# Altium schematic sheet P07-LCD.SchDoc
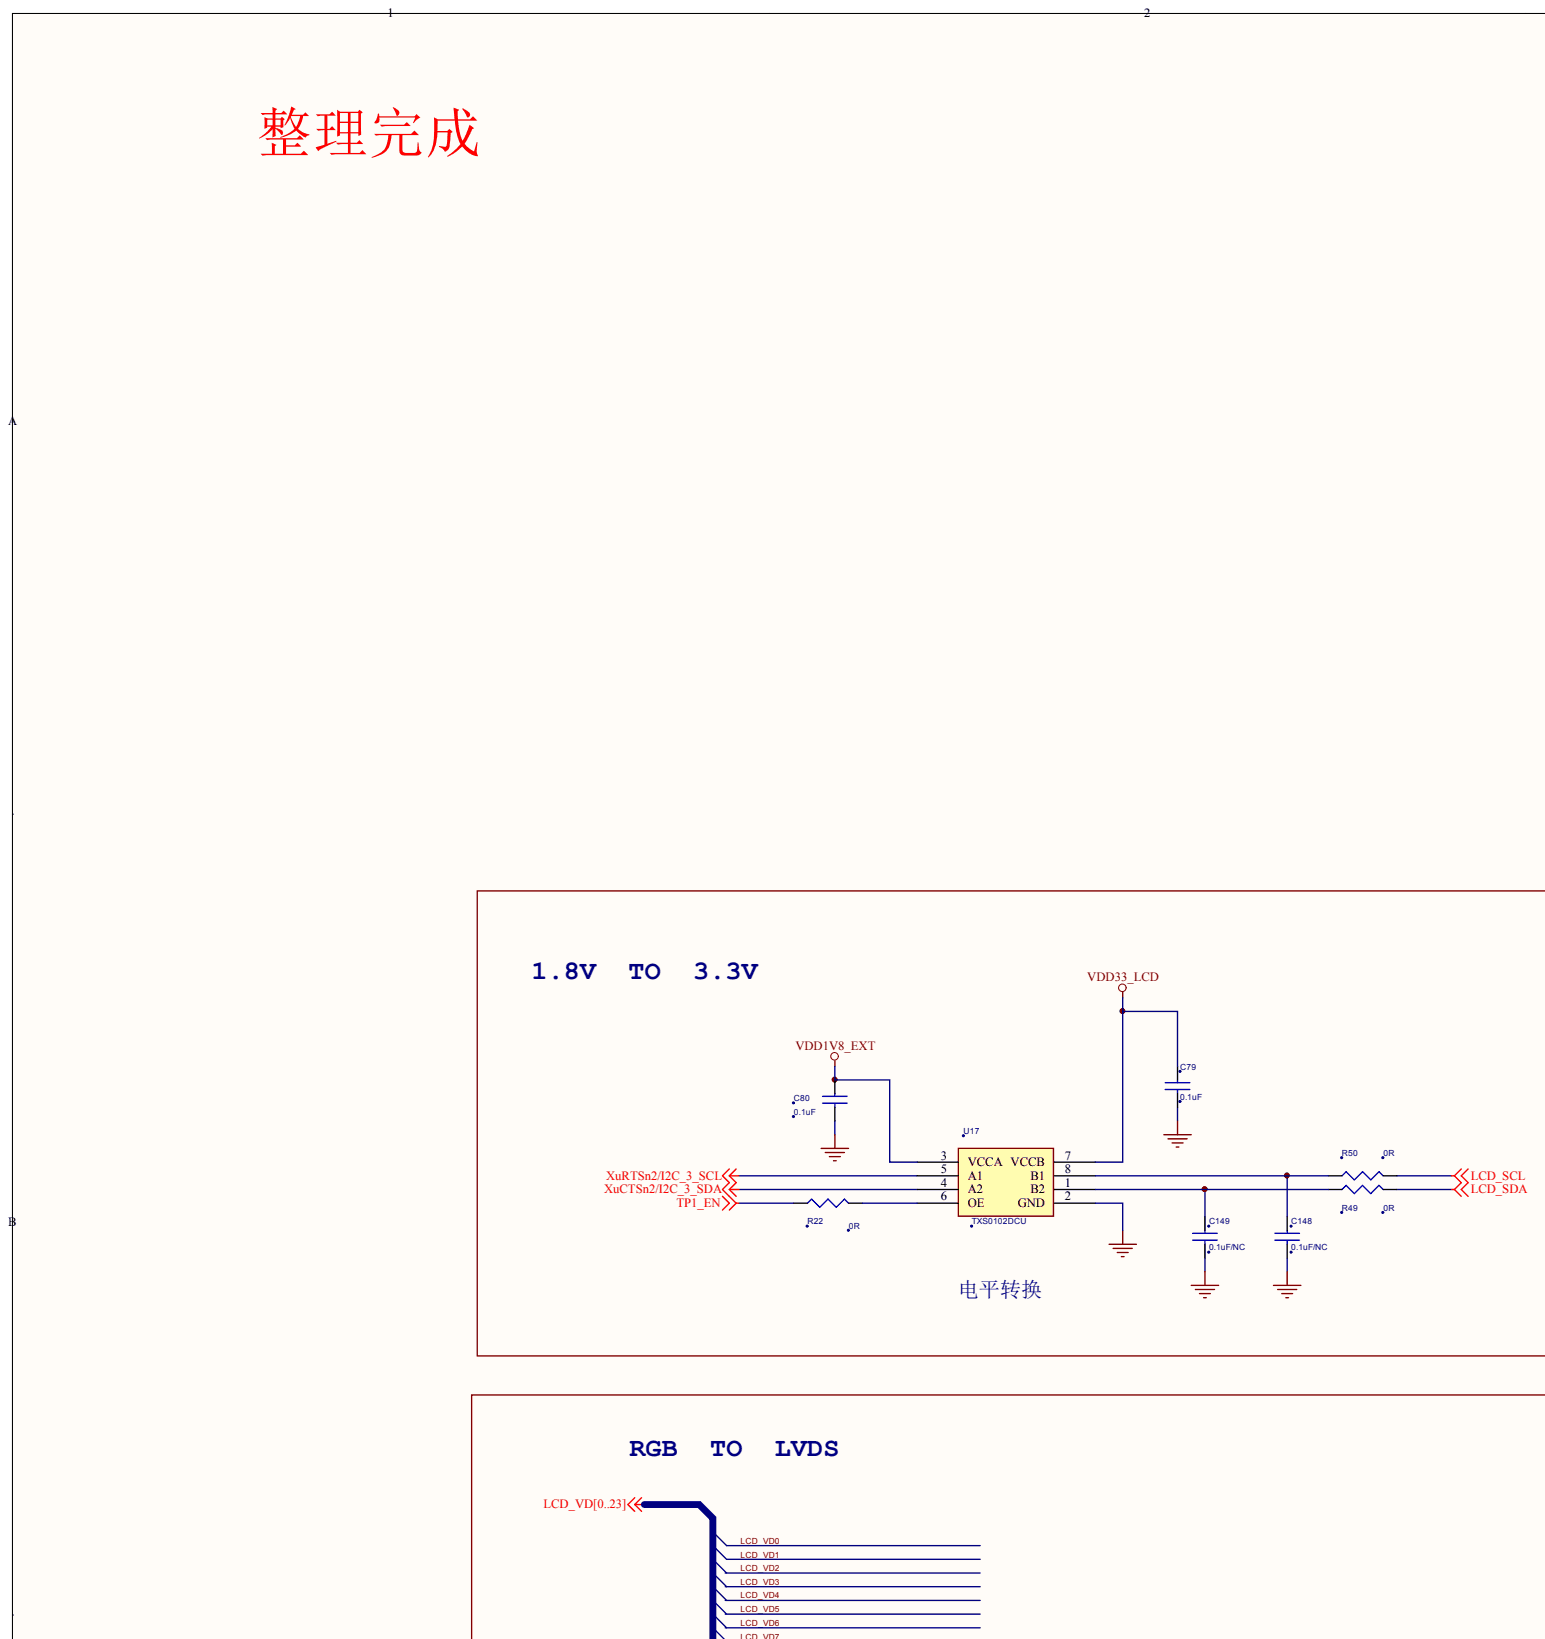
[diagram p0: P07-LCD.SchDoc, top left]
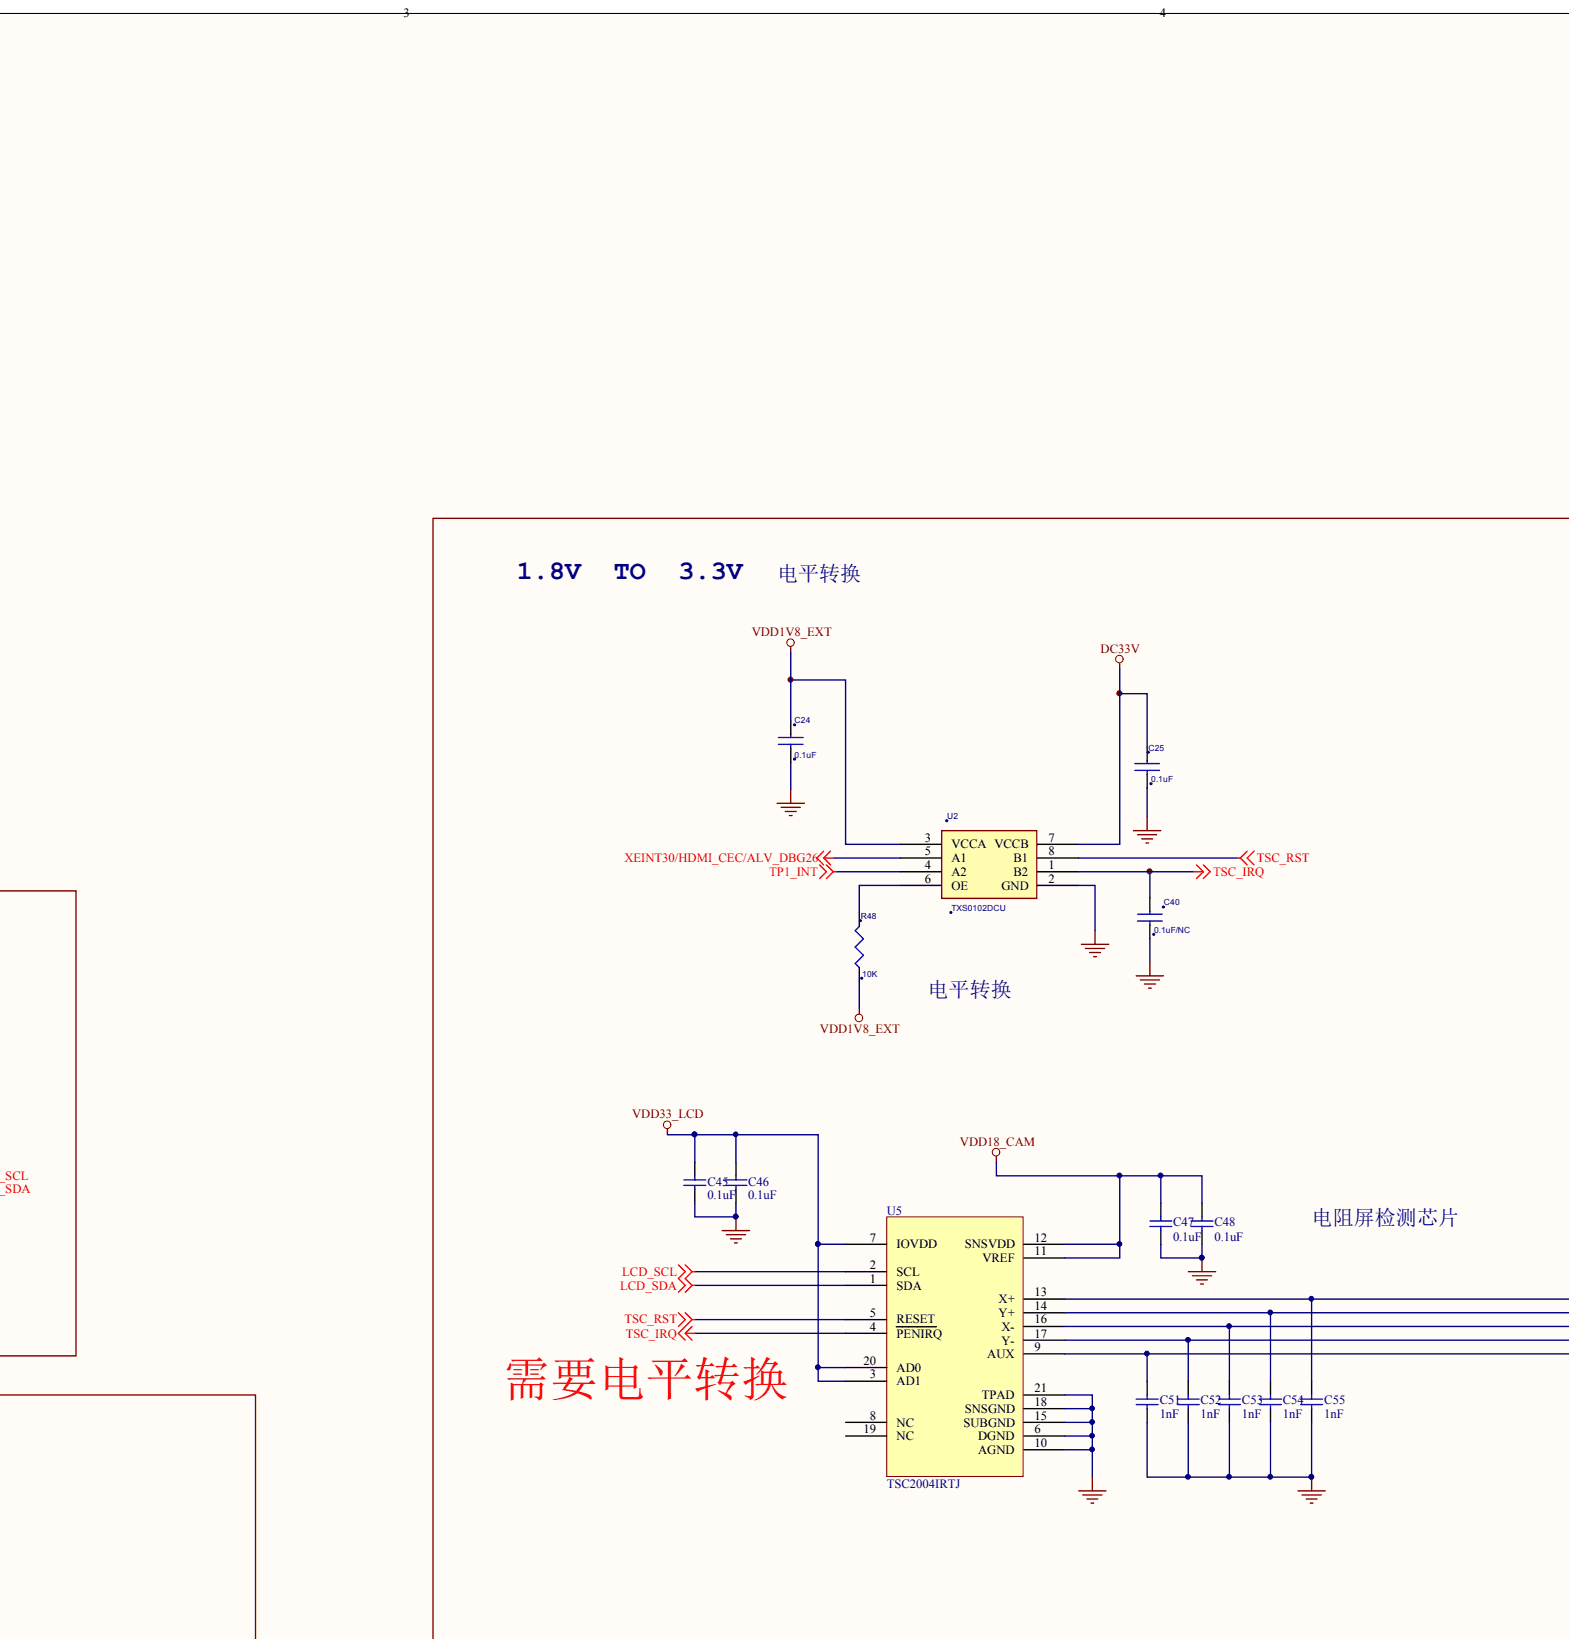
[diagram p1: P07-LCD.SchDoc, top centre]
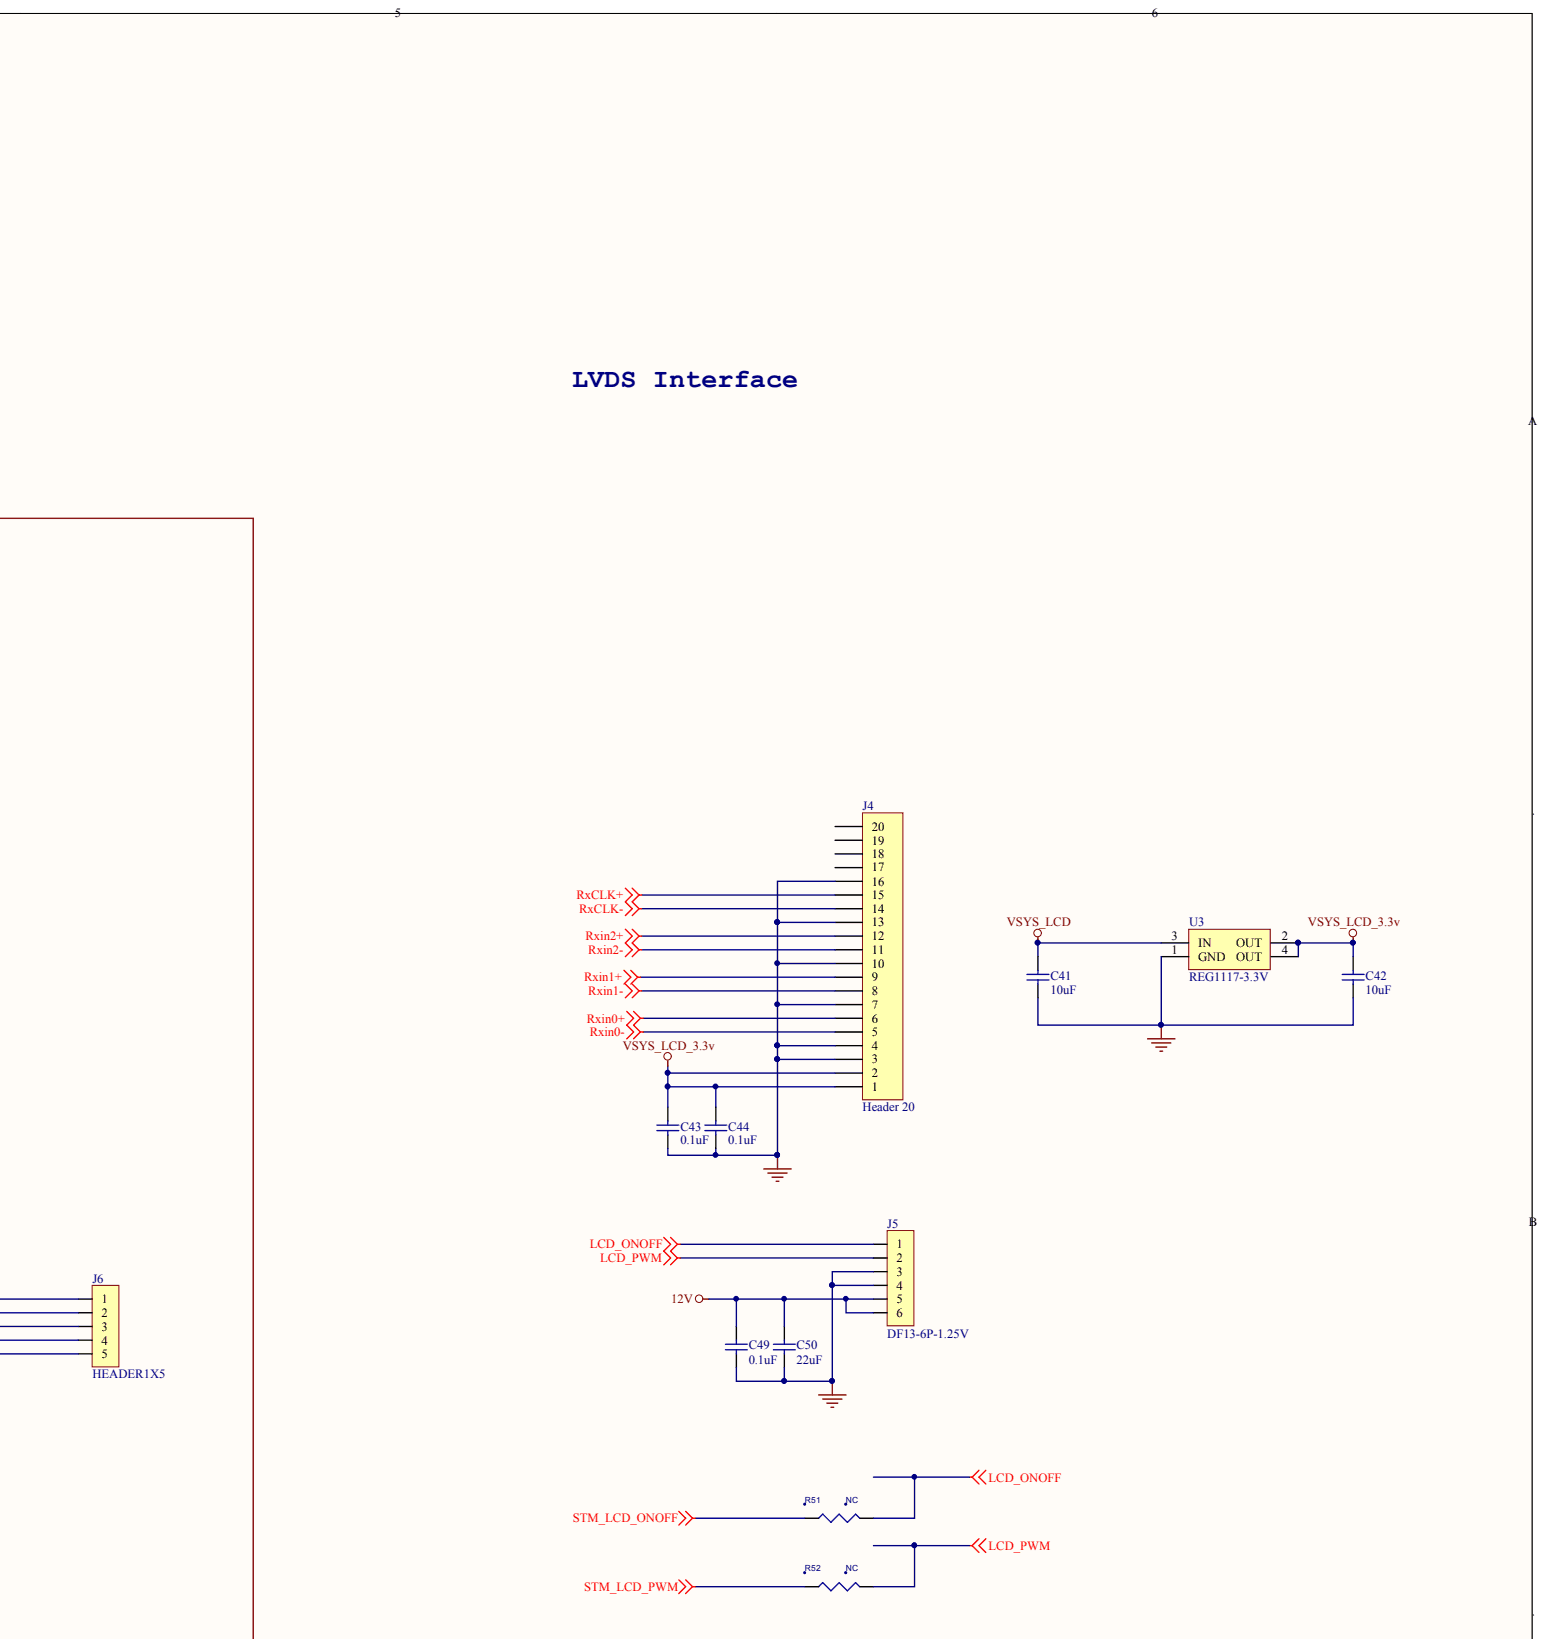
[diagram p2: P07-LCD.SchDoc, top right]
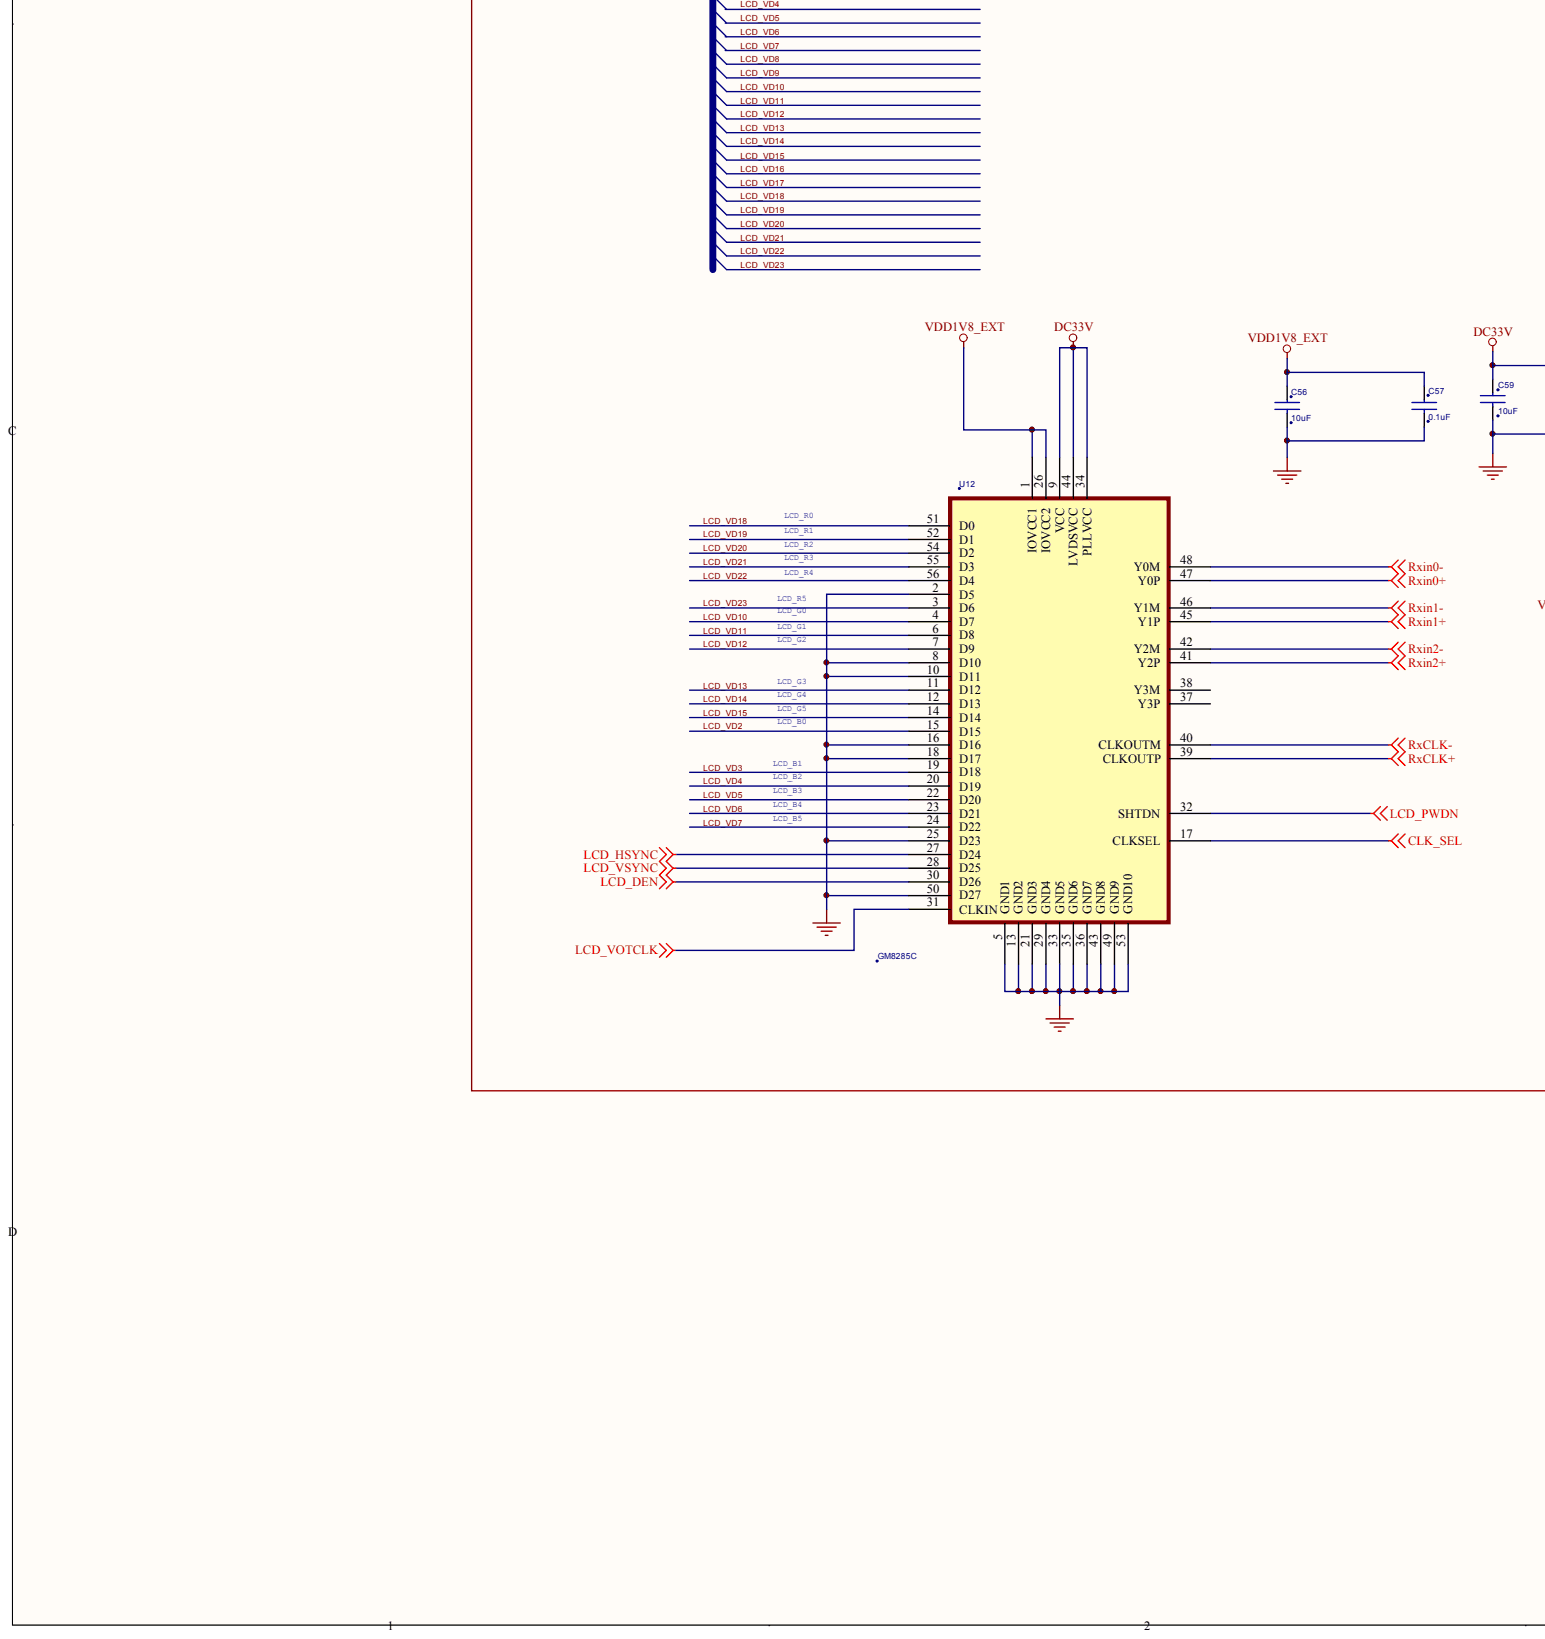
[diagram p3: P07-LCD.SchDoc, bottom left]
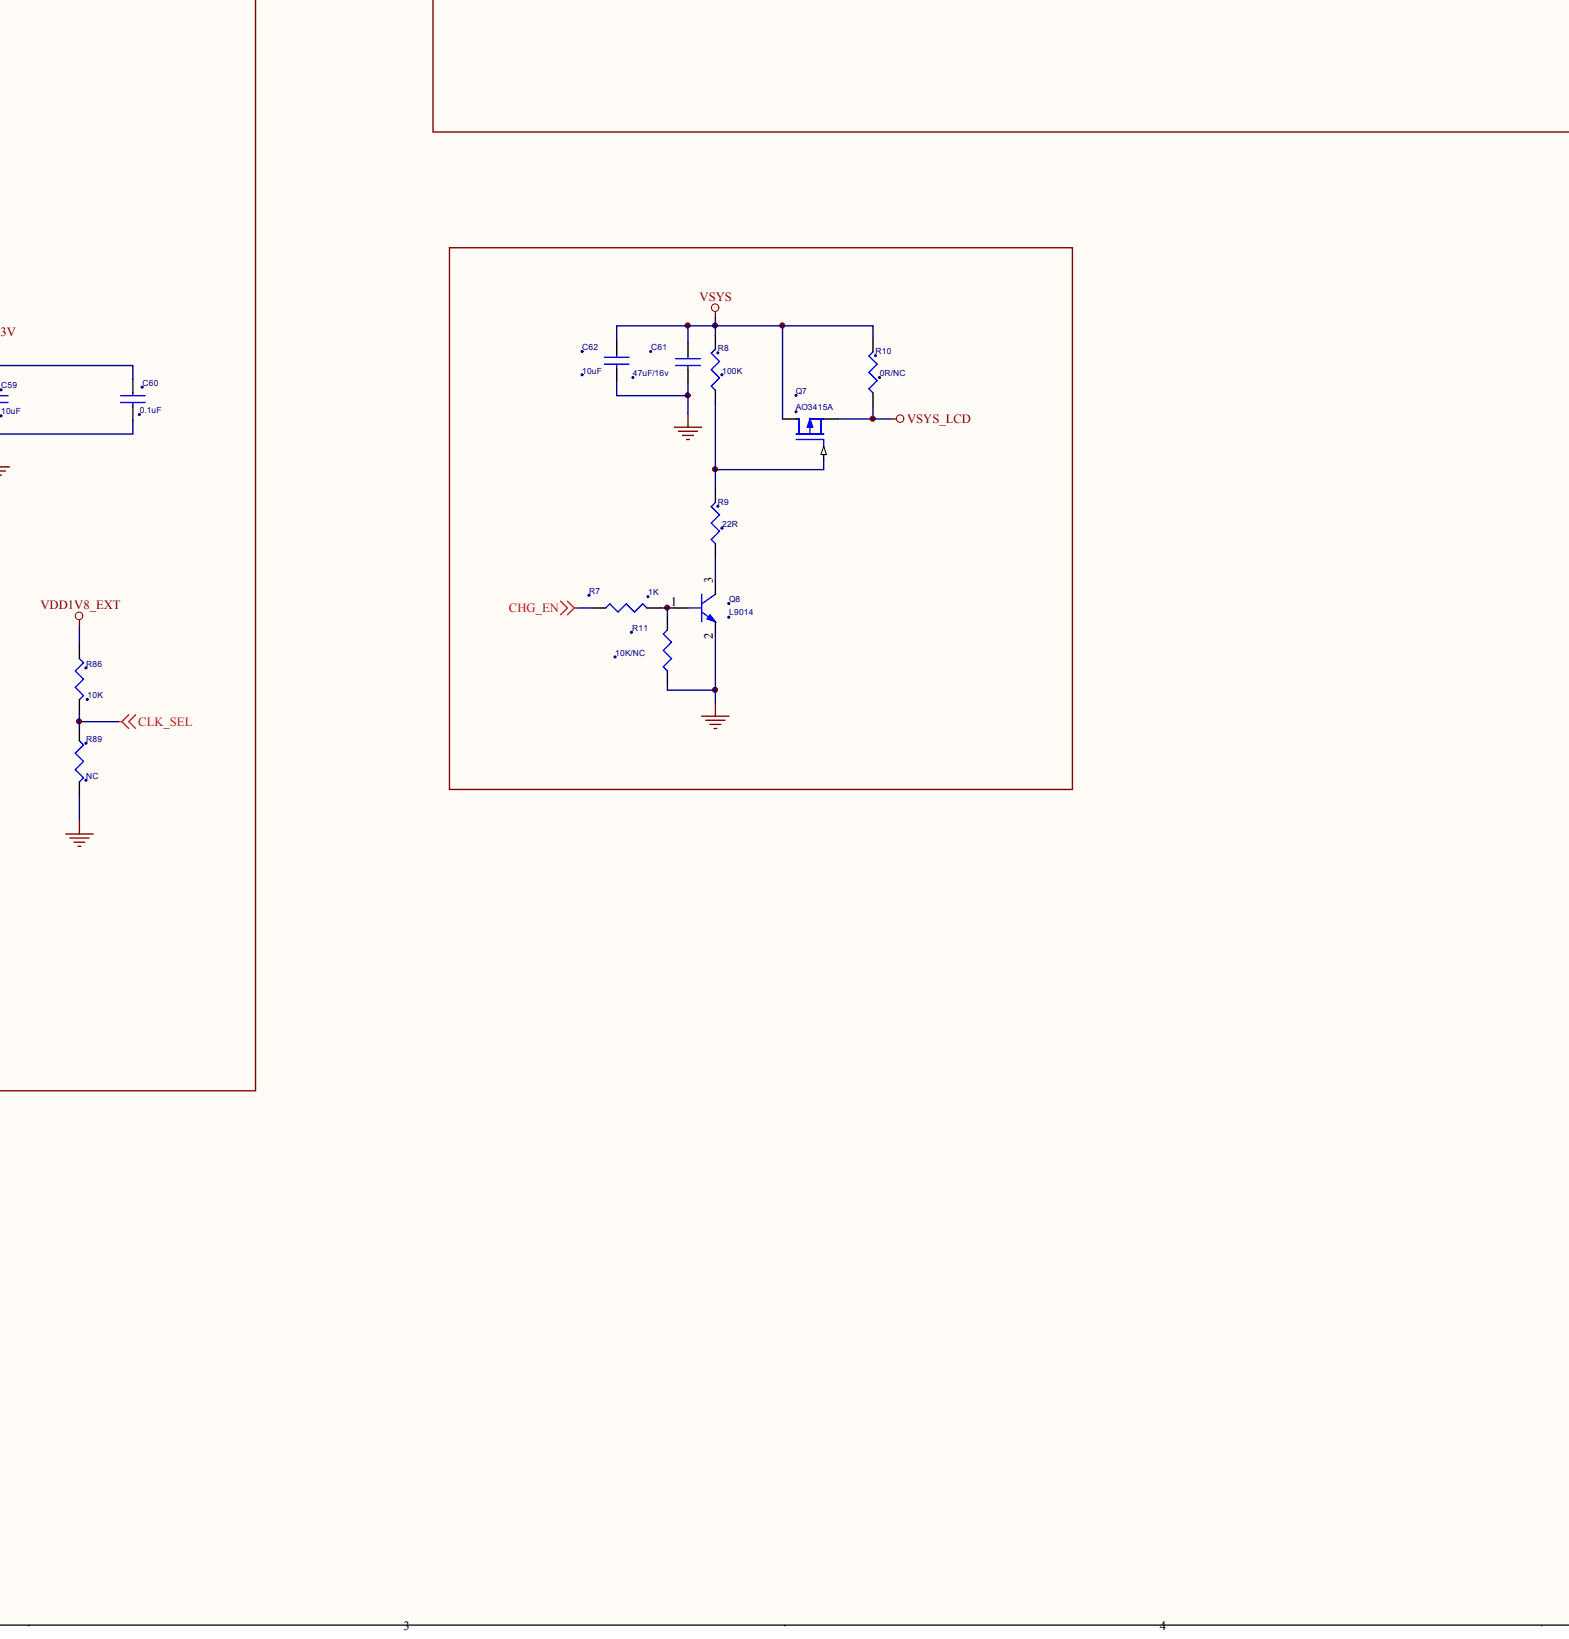
[diagram p4: P07-LCD.SchDoc, bottom centre]
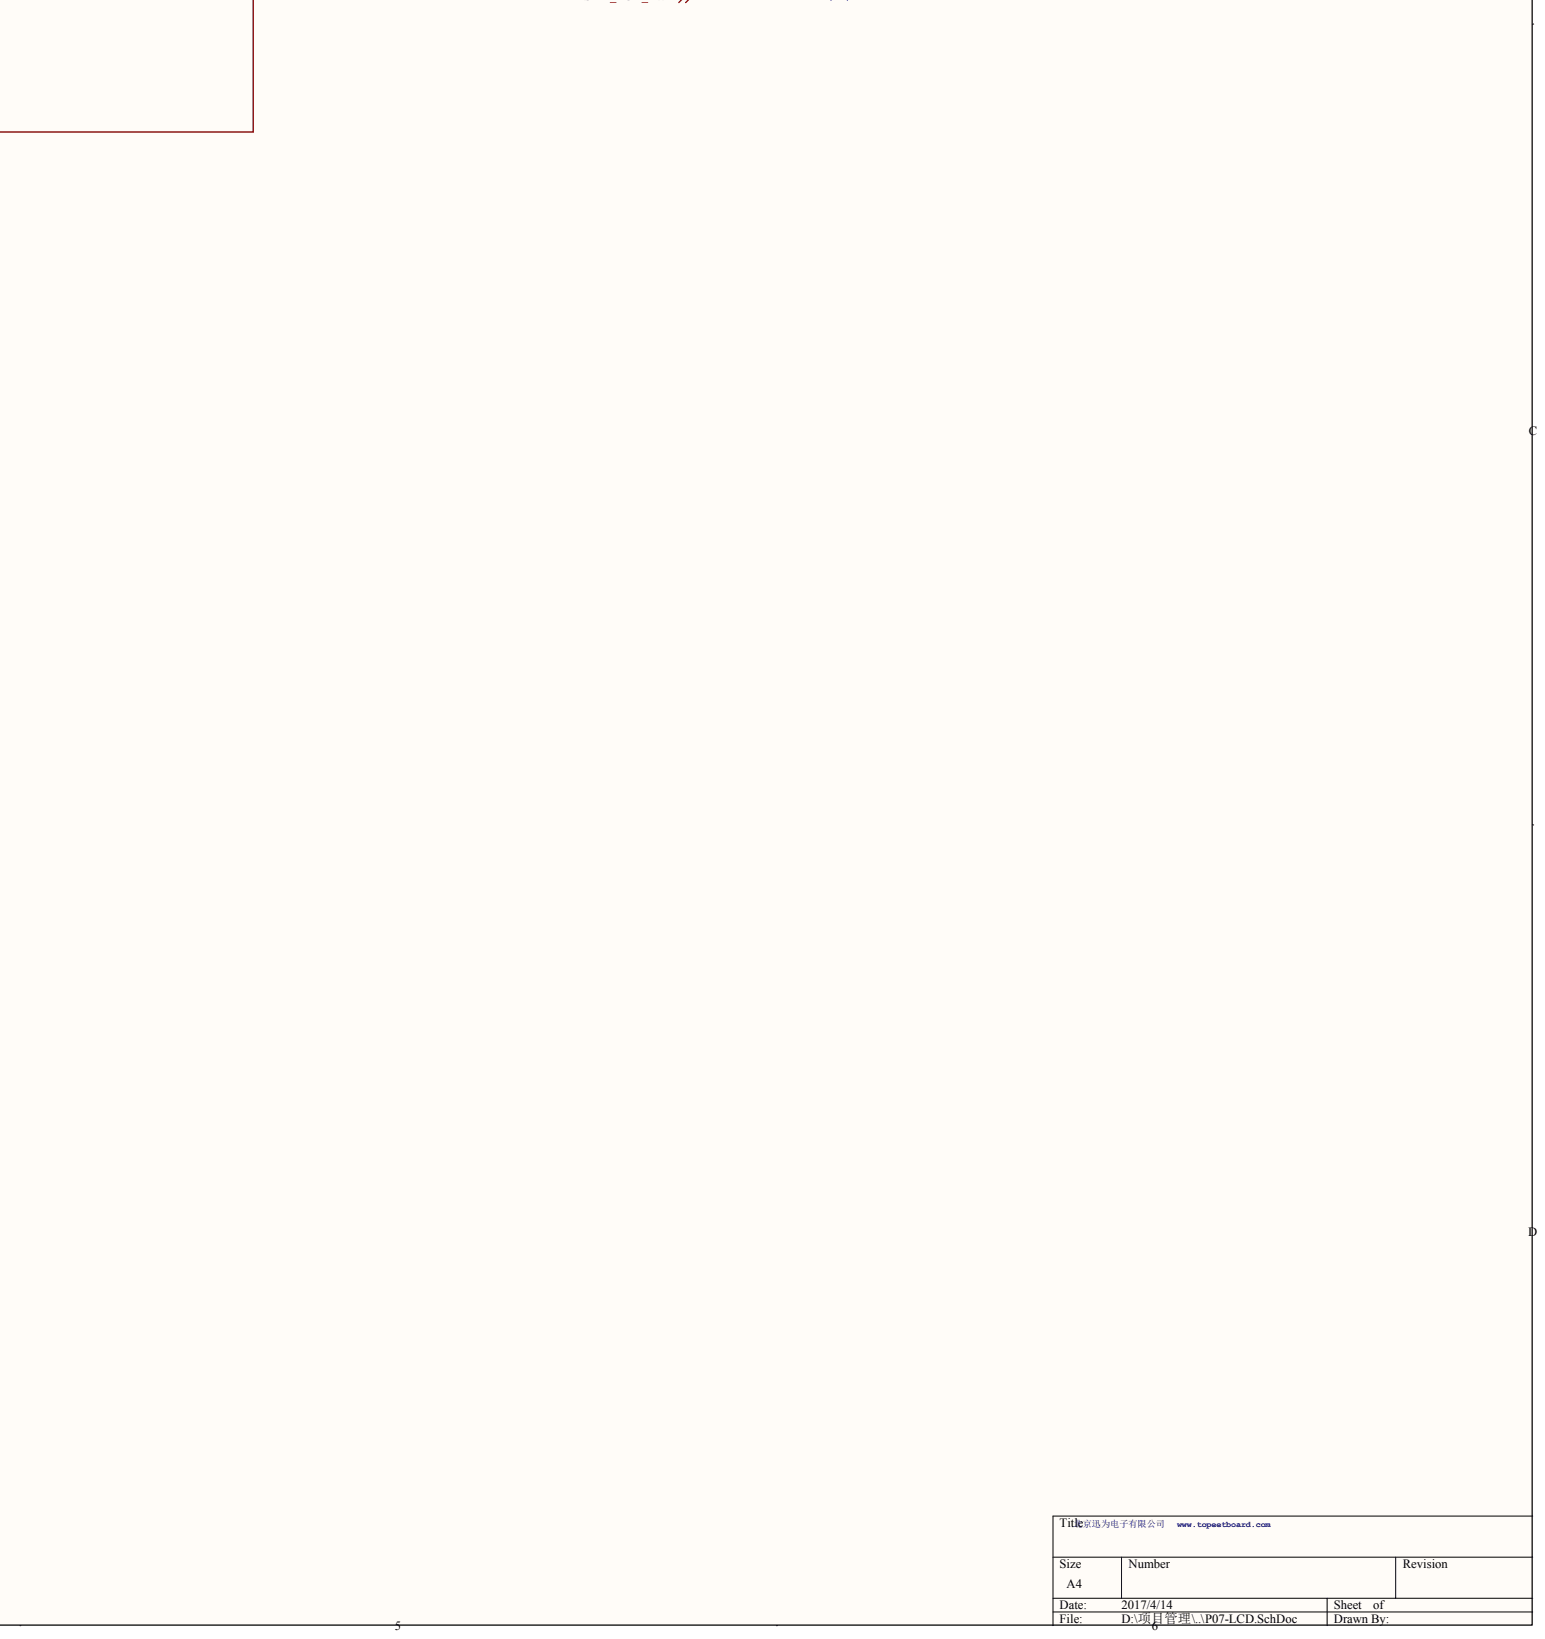
[diagram p5: P07-LCD.SchDoc, bottom right]

## Parts
C24  0.1uF
C25  0.1uF
C40  0.1uF/NC
C41  10uF
C42  10uF
C43  0.1uF
C44  0.1uF
C45  0.1uF
C46  0.1uF
C47  0.1uF
C48  0.1uF
C49  0.1uF
C50  22uF
C51  1nF
C52  1nF
C53  1nF
C54  1nF
C55  1nF
C56  10uF
C57  0.1uF
C59  10uF
C60  0.1uF
C61  47uF/16v
C62  10uF
C79  0.1uF
C80  0.1uF
C148  0.1uF/NC
C149  0.1uF/NC
J4  Header 20
J5  DF13-6P-1.25V
J6  HEADER1X5
Q7  AO3415A
Q8  L9014
R7  1K
R8  100K
R9  22R
R10  0R/NC
R11  10K/NC
R22  0R
R48  10K
R49  0R
R50  0R
R51  NC
R52  NC
R86  10K
R89  NC
U2  TXS0102DCU
U3  REG1117-3.3V
U5  TSC2004IRTJ
U12  GM8285C
U17  TXS0102DCU

## Nets
12V: C49.2 C50.2 J5.5 J5.6
CHG_EN: R7.2
CLK_SEL: R86.2 R89.1 U12.17(CLKSEL)
DC33V: C25.1 C59.1 C60.1 U2.7(VCCB) U12.9(VCC) U12.34(PLLVCC) U12.44(LVDSVCC)
GND: C24.2 C25.2 C40.2 C41.1 C42.1 C43.1 C44.1 C45.1 C46.1 C47.1 C48.1 C49.1 C50.1 C51.1 C52.1 C53.1 C54.1 C55.1 C56.2 C57.2 C59.2 C60.2 C61.2 C62.2 C79.2 C80.2 C148.2 C149.2 J4.3 J4.4 J4.7 J4.10 J4.13 J4.16 J5.3 J5.4 Q8.2 R11.2 R89.2 U2.2(GND) U3.1(GND) U5.6(DGND) U5.10(AGND) U5.15(SUBGND) U5.18(SNSGND) U5.21(TPAD) U12.2(D5) U12.5(GND1) U12.8(D10) U12.10(D11) U12.13(GND2) U12.16(D16) U12.18(D17) U12.21(GND3) U12.25(D23) U12.29(GND4) U12.33(GND5) U12.35(GND6) U12.36(GND7) U12.43(GND8) U12.49(GND9) U12.50(D27) U12.53(GND10) U17.2(GND)
LCD_DEN: U12.30(D26)
LCD_HSYNC: U12.27(D24)
LCD_ONOFF: J5.1 R51.2
LCD_PWDN: U12.32(SHTDN)
LCD_PWM: J5.2 R52.2
LCD_SCL: R50.2 U5.2(SCL)
LCD_SDA: R49.2 U5.1(SDA)
LCD_VOTCLK: U12.31(CLKIN)
LCD_VSYNC: U12.28(D25)
RXCLK+: J4.15 U12.39(CLKOUTP)
RXCLK-: J4.14 U12.40(CLKOUTM)
RXIN0+: J4.6 U12.47(Y0P)
RXIN0-: J4.5 U12.48(Y0M)
RXIN1+: J4.9 U12.45(Y1P)
RXIN1-: J4.8 U12.46(Y1M)
RXIN2+: J4.12 U12.41(Y2P)
RXIN2-: J4.11 U12.42(Y2M)
STM_LCD_ONOFF: R51.1
STM_LCD_PWM: R52.1
TP1_EN: R22.1
TP1_INT: U2.4(A2)
TSC_IRQ: C40.1 U2.1(B2) U5.4(PENIRQ)
TSC_RST: U2.8(B1) U5.5(RESET)
VDD1V8_EXT: C24.1 C56.1 C57.1 C80.1 R48.1 R86.1 U2.3(VCCA) U12.1(IOVCC1) U12.26(IOVCC2) U17.3(VCCA)
VDD18_CAM: C47.2 C48.2 U5.11(VREF) U5.12(SNSVDD)
VDD33_LCD: C45.2 C46.2 C79.1 U5.3(AD1) U5.7(IOVDD) U5.20(AD0) U17.7(VCCB)
VSYS: C61.1 C62.1 Q7.2 R8.1 R10.1
VSYS_LCD: C41.2 Q7.3 R10.2 U3.3(IN)
VSYS_LCD_3.3V: C42.2 C43.2 C44.2 J4.1 J4.2 U3.2(OUT) U3.4(OUT)
XEINT30/HDMI_CEC/ALV_DBG26: U2.5(A1)
XUCTSN2/I2C_3_SDA: U17.4(A2)
XURTSN2/I2C_3_SCL: U17.5(A1)
LCD_VD2: U12.15(D15)  [also labelled LCD_VD]
LCD_VD3: U12.19(D18)  [also labelled LCD_VD]
LCD_VD4: U12.20(D19)  [also labelled LCD_VD]
LCD_VD5: U12.22(D20)  [also labelled LCD_VD]
LCD_VD6: U12.23(D21)  [also labelled LCD_VD]
LCD_VD7: U12.24(D22)  [also labelled LCD_VD]
LCD_VD10: U12.4(D7)  [also labelled LCD_VD]
LCD_VD11: U12.6(D8)  [also labelled LCD_VD]
LCD_VD12: U12.7(D9)  [also labelled LCD_VD]
LCD_VD13: U12.11(D12)  [also labelled LCD_VD]
LCD_VD14: U12.12(D13)  [also labelled LCD_VD]
LCD_VD15: U12.14(D14)  [also labelled LCD_VD]
LCD_VD18: U12.51(D0)  [also labelled LCD_VD]
LCD_VD19: U12.52(D1)  [also labelled LCD_VD]
LCD_VD20: U12.54(D2)  [also labelled LCD_VD]
LCD_VD21: U12.55(D3)  [also labelled LCD_VD]
LCD_VD22: U12.56(D4)  [also labelled LCD_VD]
LCD_VD23: U12.3(D6)  [also labelled LCD_VD]
NetC51_2: C51.2 J6.5 U5.9(AUX)
NetC52_2: C52.2 J6.4 U5.17(Y-)
NetC53_2: C53.2 J6.3 U5.16(X-)
NetC54_2: C54.2 J6.2 U5.14(Y+)
NetC55_2: C55.2 J6.1 U5.13(X+)
NetC148_1: C148.1 R50.1 U17.8(B1)
NetC149_1: C149.1 R49.1 U17.1(B2)
NetQ7_1: Q7.1 R8.2 R9.1
NetQ8_1: Q8.1 R7.1 R11.1
NetQ8_3: Q8.3 R9.2
NetR22_2: R22.2 U17.6(OE)
NetR48_2: R48.2 U2.6(OE)
LCD_VD0:  [also labelled LCD_VD]
LCD_VD1:  [also labelled LCD_VD]
LCD_VD8:  [also labelled LCD_VD]
LCD_VD9:  [also labelled LCD_VD]
LCD_VD16:  [also labelled LCD_VD]
LCD_VD17:  [also labelled LCD_VD]

Not connected: J4 17 18 19 20; U5 8(NC) 19(NC); U12 37(Y3P) 38(Y3M)

## Library data of the parts
U3 = (no symbol name): Package Information: Latest Revision: revC; Published: 8-Jun-2000; Revision: 17-Jul-2002: Re-released for DXP Platform.; Datasheet: Latest Revision: Sep-1997; Package Reference: ZZ311; Publisher: Altium Limited
C24 C25 C40-C57 C59-C62 C79 C80 C148 C149 J4-J6 Q7 Q8 R7-R11 R22 R48-R52 R86 R89 U2 U5 U12 U17 = (no symbol name)
  C41 C42: Published: 8-Jun-2000; LatestRevisionDate: 17-Jul-2002; LatestRevisionNote: Re-released for DXP Platform.; PackageReference: RAD-0.3; Value: 10uF
  C43 C44 C49: Published: 8-Jun-2000; LatestRevisionDate: 17-Jul-2002; LatestRevisionNote: Re-released for DXP Platform.; PackageReference: RAD-0.3; Value: 0.1uF
  C50: Published: 8-Jun-2000; LatestRevisionDate: 17-Jul-2002; LatestRevisionNote: Re-released for DXP Platform.; PackageReference: RAD-0.3; Value: 22uF
  J4: LatestRevisionDate: 17-Jul-2002; LatestRevisionNote: Re-released for DXP Platform.
  C41-C44 C49 C50 J4: Publisher: Altium Limited
